# Altium schematic sheet ESE3190_Metal_Detector.SchDoc(ESE3190_Metal_Detector)
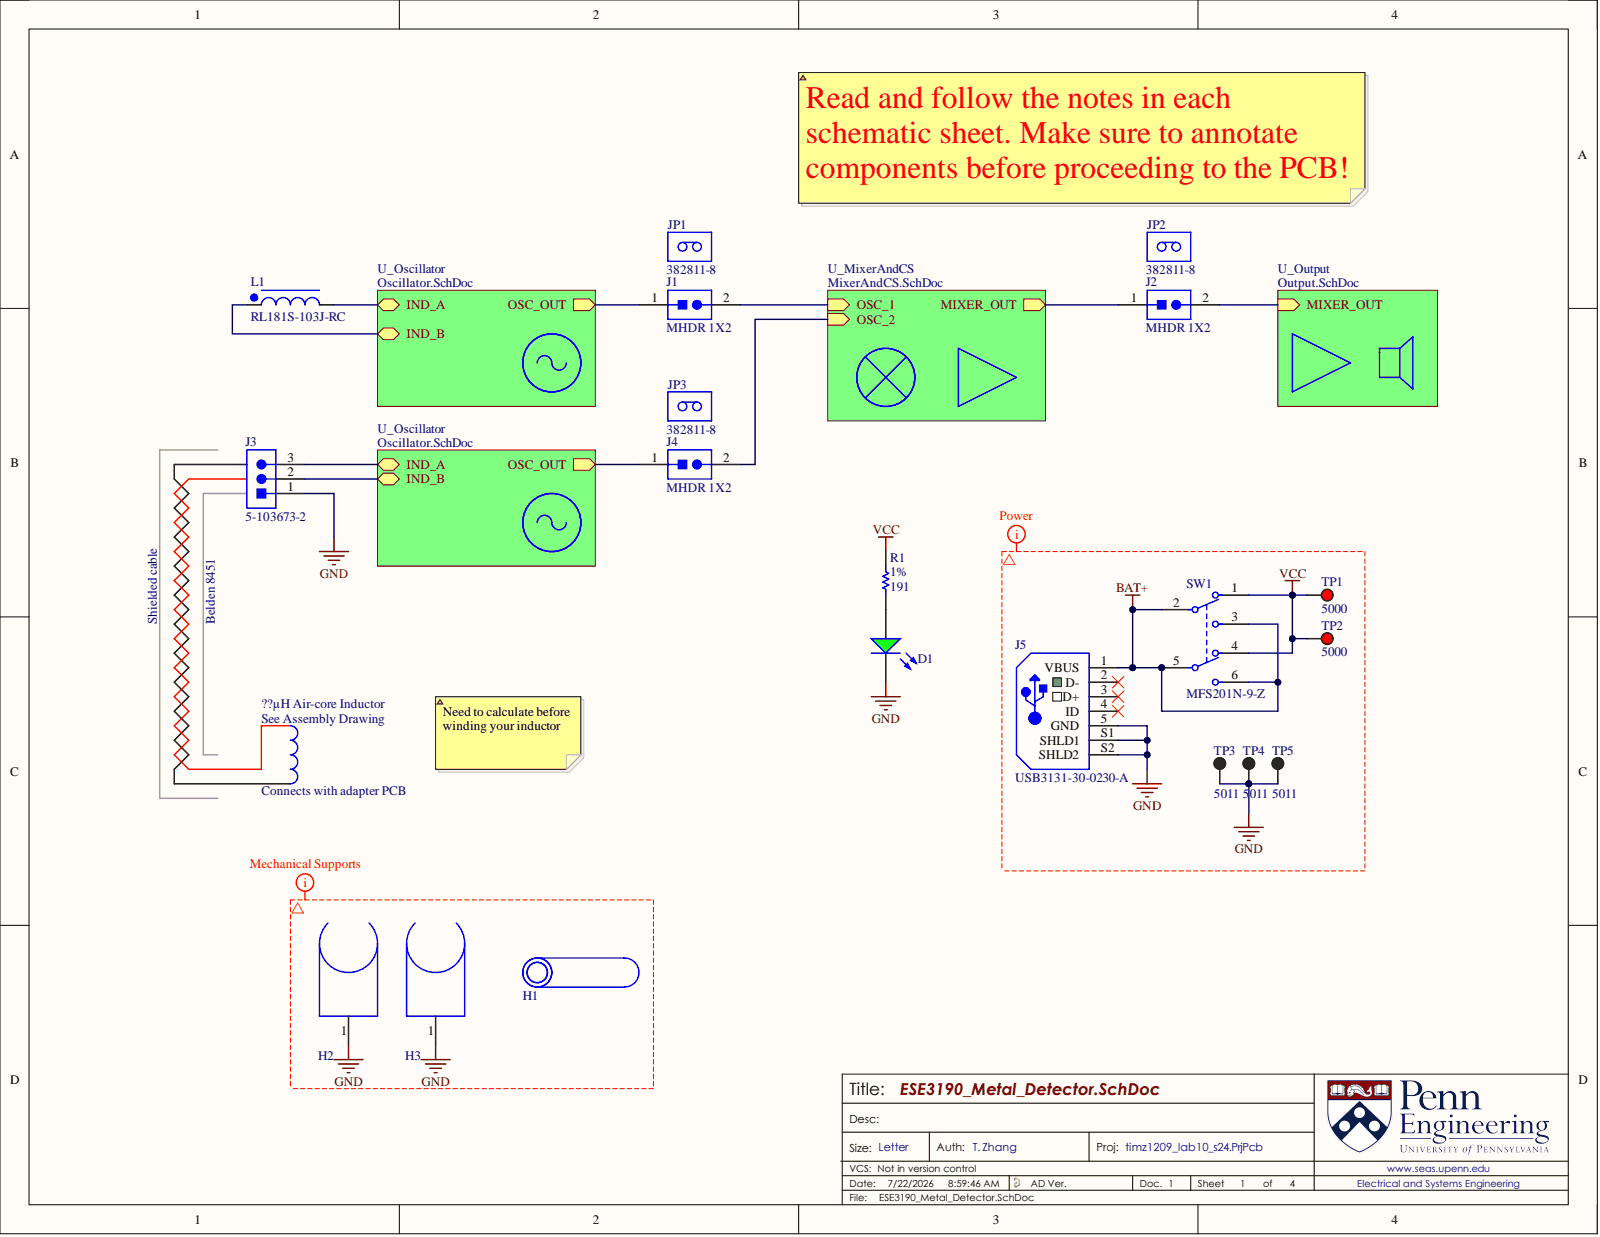
[diagram p0: ESE3190_Metal_Detector.SchDoc]

## Parts
D1  WP7113SGD  (value not drawn)
H1  3/4 Inch PVC Pipe  (value not drawn)
H2  3D Printed Pipe Clip  (value not drawn)
H3  3D Printed Pipe Clip  (value not drawn)
J1  MHDR 1X2
J2  MHDR 1X2
J3  5-103673-2
J4  MHDR 1X2
J5  USB3131-30-0230-A
JP1  382811-8  (mechanical)
JP2  382811-8  (mechanical)
JP3  382811-8  (mechanical)
L1  RL181S-103J-RC
R1  value 191  Tolerance: 1%  (hidden comment: RES THT 0207 191 1%)
SW1  MFS201N-9-Z
TP1  5000
TP2  5000
TP3  5011
TP4  5011
TP5  5011

## Nets
BAT+: J5.1(VBUS) SW1.2 SW1.3 SW1.5 SW1.6
GND: D1.K H2.1 H3.1 J3.1 J5.5(GND) J5.S1(SHLD1) J5.S2(SHLD2) TP3.1 TP4.1 TP5.1
VCC: R1.2 SW1.1 SW1.4 TP1.1 TP2.1
NetD1_A: D1.A R1.1
NetJ1_1: J1.1
NetJ1_2: J1.2
NetJ2_1: J2.1
NetJ2_2: J2.2
NetJ3_2: J3.2
NetJ3_3: J3.3
NetJ4_1: J4.1
NetJ4_2: J4.2
NetJ5_2: J5.2(D-)
NetJ5_3: J5.3(D+)
NetJ5_4: J5.4(ID)
NetL1_1: L1.1
NetL1_2: L1.2

## Library data of the parts
D1 = CMP-00016-1: Single Color LED, Super Bright Green, Diffused Green, T-1 3/4, 5mm; Current: 20mA; Forward Voltage: 2.2V; Millicandela Rating: 40mcd; Package (Case): T-1 3/4; Wavelength - Dominant: 568nm; Wavelength - Peak: 565nm; Color: Green; Component Kind: Standard; Library Name: University of Pennsylvania (ESE Labs)
H1 = PVC Pipe: Component Kind: Standard; Library Name: Metal Detector Custom Parts.SchLib
H2 H3 = Pipe Clip: Component Kind: Standard; Library Name: Metal Detector Custom Parts.SchLib
J1 J2 J4 = CMP-000021-1: Header, 2-Pin, 0.1in Pitch, Male; Component Kind: Standard; Library Name: University of Pennsylvania (ESE Labs)
J3 = CMP-00385-1: Board Connector, 3 Contact(s), 1 Row(s), Male, Right Angle, Solder Terminal, Black Insulator; Additional Feature: POLARIZED; DIN Conformance: No; Mating Information: MULTIPLE MATING PARTS AVAILABLE; Number of Contacts: 3; Number of Rows Loaded: 1; Option: General Purpose; Component Kind: Standard; Connector Type: BOARD CONNECTOR; Contact Finish - Mating: NOT SPECIFIED; Contact Finish - Termination: TIN; Contact Gender: Male; Contact Material: Brass; Filter Feature: No; Flammability Rating: UL94 V-0; IEC Conformance: No; JESD-609 Code: e3; Library Name: University of Pennsylvania (ESE Labs); MIL Conformance: No; Mixed Contacts: No; Mounting Style: RIGHT ANGLE; Mounting Technology: Board; Reach SVHC Compliant: Yes; RoHS Compliant: Yes; Terminal Pitch: 2.54mm; Termination: Solder
J5 = CMP-000047-1: USB Connector, 5 Contact(s), Male, Straight, Solder Terminal, Locking, Receptacle; Board Mounting Option: LOCATING POSTS; Body Breadth: 0.114inch; Body Depth: 0.22inch; Body Length: 0.313inch; Connector Type: TELECOM AND DATACOM CONNECTOR; Contact Resistance: 30mR; Dielectric Withstanding Voltage (AC): 100V; Durability: 10000Cycles; Insulation Resistance: 1GR; Number Of Connectors: 1; Number of Contacts: 5; Number Of PCB Rows: 2; PCB Contact Pattern: STAGGERED; PCB Contact Row Spacing: 0.9906mm; Plating Thickness: 30uin; Polarization Key: POLAR PIN POSITION; Rated Current (Signal): 1A; Reference Standard: UL; Reliability: COMMERCIAL; Body/Shell Style: RECEPTACLE; Component Kind: Standard; Contact Finish - Mating: GOLD (30) OVER NICKEL (50); Contact Finish - Termination: Gold (Au) - with Nickel (Ni) barrier; Contact Gender: Male; Contact Material: Copper Alloy; Contact Style: USB; Insulation Material: Polymer; JESD-609 Code: e4; Library Name: University of Pennsylvania (ESE); Max Operating Temperature: 85°C; Min Operating Temperature: -55°C; Mount: Board; Mounting Option 1: LOCKING; Mounting Style: STRAIGHT; REACH SVHC: Yes; RoHS Compliant: Yes; Shell Finish: Tin; Shell Material: Stainless Steel; Terminal Pitch: 1.2954mm; Termination: Solder
JP1-JP3 = CMP-00382-2: Economy Shunt Assembly 5.08X2.54X6.35mm; Manufacturer: Tyco Electronics; ComponentLink1Description: Manufacturer Website; ComponentLink1URL: http://www.tycoelectronics.com; ComponentLink2Description: Component Datasheet; ComponentLink2URL: http://www.te.com/commerce/DocumentDelivery/DDEController?Action=srchrtrv&DocNm=382811&DocType=Customer+Drawing&DocLang=English; ComponentLink3Description: Octopart Link; ComponentLink3URL: http://octopart.com/search?q=382811-8; ComponentLink4Description: Product Page; ComponentLink4URL: http://www.te.com/catalog/pn/en/382811-8?RQPN=382811-8; Description in Visual: Economy Shunt Assembly; Design Item ID: 382811-8; Length: 5.08mm; Library Name: University of Pennsylvania (ESE Labs); Manufacturer P/N: 382811-8; Material: Black Polyester; P/N: 382811-8; Source: Shunts and Jumpers.SchLib
L1 = CMP-00383-1: General Purpose Inductor, 10000uH, 5%, 1 Element, Ferrite-Core; Manufacturer Series: RL181S; DC Resistance (DCR): 12.1; Inductance: 10mH; Inductor Application: POWER INDUCTOR; Lead Diameter: 0.7mm; Lead Length: 5mm; Number of Functions: 1; Number of Terminals/Pins: 2; Package Diameter: 10.5mm; Package Length: 14mm; Quality Factor-Min (at L-nom): 100; Rated Current-Max: 25mA; Self Resonant Frequency: 250kHz; Shape/Size Description: CYLINDRICAL PACKAGE; Shielding: Shielded; Surface Mount: No; Test Frequency: 1kHz; Component Kind: Standard; Construction: Shielded; Core Material: Ferrite; Inductance-Nom (L): 10000uH; Inductor Type: GENERAL PURPOSE INDUCTOR; JESD-609 Code: e3; Lead Pitch: 5mm; Library Name: University of Pennsylvania (ESE Labs); Max Operating Temperature: 105°C; Min Operating Temperature: -55°C; Mount: THT; Package Style: RadialMeter; Packaging: Bulk; Pins: 2; REACH SVHC: Yes; RoHS: Yes; RoHS Compliant: Yes; Series: RL181S; Terminal Finish: Tin; Terminal Placement: Radial; Termination: Wire; Tolerance: 5%
R1 = CMP-007-000426-2: Resistor Through Hole, 191Ohms, DIN0207 (L6.3mm D2.5mm) Footprint, 1% Tolerance 0.25W; Manufacturer: Yaego; Manufacturer P/N: MFR-25FRF52-191R; Component Kind: Standard; Library Name: University of Pennsylvania (ESE Labs); Mount: THT; P/N: RES THT 0207 191 1%; PCB Package: DIN0207; Power Rating: 0.25W
SW1 = CMP-00062-1: Slide Switch, 2 Positions, DPDT, On-on, Latched, 1A, 30VDC, 6 PCB Hole Cnt, Solder Terminal, Through Hole-straight; Manufacturer Series: MFS; Body Breadth: 7.5inch; Body Height: 9mm; Body Length or Diameter: 17mm; Contact AC Max Rating R Load: 1A@125VAC; Contact DC Max Rating R Load: 1A@30VDC; Contact Voltage(AC)-Max: 125V; Contact Voltage(DC)-Max: 30V; Electrical Life: 5000Cycles; Operating Force-Max: 4.41N; Switch Type: Snap Acting/Limit; Component Kind: Standard; Contact Current(AC)-Max: 1A; Contact Current(DC)-Max: 1A; Library Name: University of Pennsylvania (ESE Labs); Max Operating Temperature: 70°C; Min Operating Temperature: -10°C; Mount: Through Hole; RoHS Compliant: Yes; Switch Action: LATCHED; Switch Function: DPDT; Termination: Solder
TP1 TP2 = CMP-024-000000-1: Test Point, Red, 1-Pin THD, RoHS, Bulk; Brand: KeystoneElectronics; Mounting Hole Size: 1mm; Outside Diameter: 2.54mm; Plating: Silver; Color: Red; Component Kind: Standard; Component Type: Standard; Contact Material: PhosphorBronze; Datasheet URL: https://ciiva.com/datasheet-click-2917627?src=ciiva&sig=bd195616; Datasheet Version: Rev. G, 12/2008; Design Item ID: 5000; Length: 7.62mm; Library Name: University of Pennsylvania (ESE Labs); Manufacturer: KeystoneElectronics; Manufacturer URL: http://www.keyelco.com/; Material: Nylon; Packaging: Bulk; Pin Count: 1; Product: TestPoints; Product Category: CircuitBoardHardware-PCB; RoHS: True; Source: Keystone 500x Series.SchLib; Type: Miniature
TP3-TP5 = CMP-024-000010-1: Large THT Test Point, Black; Component Kind: Standard; Library Name: University of Pennsylvania (ESE Labs)
